# Altium schematic sheet RUMBA_plus.SchDoc (continued)

## Library data of the parts
5V 12V FAN0 FAN1 PS_ON PWR = Header 2: Header, 2-Pin; Manufacturer 1: Generic Header; Note: Approved sub OK; Component Kind: Standard; Signal Integrity: Connector; Library Name: RUMBA_v2.SCHLIB
C1 C21-C25 C30-C32 = CapE-6.3-100uF-35V: CAP ALUM 100UF 20% 35V SMD; Note: Approved sub OK; Component Kind: Standard; Published: 8-Jun-2000; Revision: July-2002: Re-released for DXP Platform.; Signal Integrity: Cap Pol; Simulation: CAP; Supplier 1: Digi-Key; Supplier Part Number 1: PCE5016CT-ND; Library Name: RUMBA_v2.SCHLIB; Publisher: Altium Limited
C2 C35 = CapC-0805-4.7uF 10V: CAP CER 4.7UF 10V X7R 0805; Note: Approved sub OK; Tolerance: 10%; Component Kind: Standard; Library Name: main_library.SCHLIB; Package: 0805; Revision: July-2002: Re-released for DXP Platform.; Signal Integrity: Cap Semi; Simulation: CAP; Supplier 1: Digi-Key; Supplier Part Number 1: 445-14527-1-ND; Type: X7R; Voltage: 10V
C3 C17 C36 = CapC-1210-4.7uF-50V: CAP CER 4.7UF 50V X7R 1210; Note: Approved sub OK; Component Kind: Standard; Published: 8-Jun-2000; Revision: July-2002: Re-released for DXP Platform.; Supplier 1: Digi-Key; Supplier Part Number 1: 399-6785-1-ND; Library Name: picoprint_library.SCHLIB; Publisher: Altium Limited
C4-C7 C10 C11 C13 C16 C18 C33 C34 = CapC-0603-100nF: CAP CER 0.1UF 50V X7R 0603; Note: Approved sub OK; Component Kind: Standard; Published: 8-Jun-2000; Revision: July-2002: Re-released for DXP Platform.; Signal Integrity: Cap Semi; Simulation: CAP; Supplier 1: Digi-Key; Supplier Part Number 1: 311-1344-1-ND; Library Name: RUMBA_v2.SCHLIB; Publisher: Altium Limited
C8 C14 C15 C19 C20 = CapC-0603-22pF: CAP CER 22PF 50V X7R 0603; Note: Approved sub OK; Component Kind: Standard; Published: 8-Jun-2000; Revision: July-2002: Re-released for DXP Platform.; Signal Integrity: Cap Semi; Simulation: CAP; Supplier 1: Digi-Key; Supplier Part Number 1: 478-6204-1-ND; Library Name: RUMBA_v2.SCHLIB; Publisher: Altium Limited
C9 C12 C26-C29 = CapC-0603-1uF 50V: CAP CER 1UF 16V X7R 0603; Note: Approved sub OK; Tolerance: 10%; Component Kind: Standard; Library Name: main_library.SCHLIB; Package: 0603; Revision: July-2002: Re-released for DXP Platform.; Signal Integrity: Cap Semi; Simulation: CAP; Supplier 1: Digi-Key; Supplier Part Number 1: 587-1241-1-ND; Type: X7R; Voltage: 16V
D2 D3 = DIODE_75V_500mW: DIODE GEN PURP 75V 150MA SOD323; Note: Approved sub OK; Ratings: 75V; 300mA; 0.5W; Value: Vf=1V @ 50mA; Component Kind: Standard; Library Name: PBL - Diodes.SCHLIB; Package / Case: SOD323; Supplier 1: Digi-Key; Supplier Part Number 1: 1N4148WXTPMSCT-ND
D4 D5 D15 = Diode Schottky 2A 40V: DIODE SCHOTTKY 40V 2A SOD123F; Note: Approved sub OK; Component Kind: Standard; Revision: July-2002: Re-released for DXP Platform.; Signal Integrity: Diode; Simulation: DIODE; Supplier 1: Digi-Key; Supplier Part Number 1: SS24FLCT-ND; Library Name: picoprint_library.SCHLIB
D6 D7 D9-D14 = DIODE_100V_1.5A_SMA: DIODE GEN PURP 100V 1.5A SMA; Diode Type: Standard; Material: Si; Note: Approved sub OK; Ratings: 100V; 1.5A; SCDType: source; Value: Vf = 1.15V @ 1.5A (Max); Component Kind: Standard; Library Name: PBL - Diodes.SCHLIB; Package / Case: DO-214AC, SMA; Supplier 1: Digi-Key; Supplier Part Number 1: S2BA-FDICT-ND
D8 = Diode Schottky - 40V 4A - SSB44-E3: DIODE SCHOTTKY 40V 4A DO214AA; Note: Approved sub OK; Component Kind: Standard; Revision: July-2002: Re-released for DXP Platform.; Supplier 1: Digi-Key; Supplier Part Number 1: SSB44-E3/52TGICT-ND; Library Name: RUMBA_library.SCHLIB
E0-E2 X Y Z = A4988BREAKOUT: Note: Approved sub OK; Supplier 1: Digi-Key; Supplier Part Number 1: S7006-ND; Library Name: RUMBA_v2.SCHLIB
E0_0 E0_1 E1_0 E1_1 E2_0 E2_1 X_1 X_2 Y_0 Y_1 Z_0 Z_1 = Header 8x1: Header, 8-Pin, Female; Manufacturer 1: Generic Header; Note: Approved sub OK; Signal Integrity: Connector; Library Name: picoprint_library.SCHLIB
E0_MOT E1_MOT E2_MOT X_MOT Y_MOT Z_MOT = Header 4_1: Header, 4-Pin; Manufacturer 1: Generic Header; Note: Approved sub OK; Component Kind: Standard; Signal Integrity: Connector; Library Name: RUMBA_v2.SCHLIB
E0_MOT_A E1_MOT_A E2_MOT_A X_MOT_A Y_MOT_A Z_MOT_A = ScrewTerminal-3.5mm-4Way: TERM BLK 4POS SIDE ENT 3.5MM PCB; Note: Approved sub OK; Component Kind: Standard; LatestRevisionDate: 17-Jul-2002; LatestRevisionNote: Re-released for DXP Platform.; Signal Integrity: Connector; Supplier 1: Digi-Key; Supplier Part Number 1: 277-5744-ND; Library Name: RUMBA_v2.SCHLIB; Publisher: Altium Limited
E0_MS E1_MS E2_MS X_MS Y_MS Z_MS = SW DIP-3: SWITCH SLIDE DIP SPST 25MA 24V; Note: Approved sub OK; Component Kind: Standard; Revision: July-2002: Re-released for DXP Platform.; Simulation: dpsw8; Supplier 1: Digi-Key; Supplier Part Number 1: 732-3853-1-ND; Library Name: RUMBA_v2.SCHLIB
  E0_MS E1_MS X_MS Y_MS Z_MS: Published: 24-Mar-1999; Publisher: Altium Limited
ENC_1-ENC_4 = Header 4: Header, 4-Pin; Manufacturer 1: Generic Header; Note: Approved sub OK; Component Kind: Standard; Library Name: Miscellaneous Connectors.IntLib; Signal Integrity: Connector
EXP1 EXP2 JTAG Thermistors = Header 5X2: Header, 5-Pin, Dual row; Manufacturer 1: Generic Header; Note: Approved sub OK; Component Kind: Standard; Signal Integrity: Connector; Library Name: RUMBA_v2.SCHLIB
EXP3 = Header 7X2: Header, 7-Pin, Dual row; Manufacturer 1: Generic Header; Note: Approved sub OK; Component Kind: Standard; Signal Integrity: Connector; Library Name: RUMBA_v2.SCHLIB
F1 F2 = Fuseholder - Mini ATC ATO 15A: FUSE HOLDER BLADE PCB; Note: Approved sub OK; Component Kind: Standard; Library Name: Schlib1.SchLib; Supplier 1: Digi-Key; Supplier Part Number 1: BK-6013-ND
F3 = Fuse - 15V 200mA Trip PTC SMD 0603: PTC RESET FUSE 9V 200MA 0603; Note: Approved sub OK; Ratings: 160mA; 63VDC; slow-blow; Component Kind: Standard; Library Name: Schlib1.SchLib; Package / Case: 0603 (1608 metric); Supplier 1: Digi-Key; Supplier Part Number 1: 507-1821-1-ND
FAN0_1 FAN1_1 HE0-HE2 = ScrewTerminal-3.5mm-2Way: TERM BLK 2POS SIDE ENT 3.5MM PCB; Note: Approved sub OK; Component Kind: Standard; Supplier 1: Digi-Key; Supplier Part Number 1: 277-5719-ND; Library Name: RUMBA_v2.SCHLIB
HB-OUT HB-PWR MAIN-PWR = ScrewTerminal-5mm-2Way: TERM BLK 2POS SIDE ENTRY 5MM PCB; Component Kind: Standard; Supplier 1: Digi-Key; Supplier Part Number 1: 277-6405-ND; Library Name: RUMBA_v2.SCHLIB
ISP1 ISP2 = Header 3X2: Header, 3-Pin, Dual row; Manufacturer 1: Generic Header; Note: Approved sub OK; Component Kind: Standard; Signal Integrity: Connector; Library Name: RUMBA_v2.SCHLIB
J2 = Micro-USB B Socket: CONN RCPT USB2.0 MICRO B SMD R/A; Note: Approved sub OK; Component Kind: Standard; Supplier 1: Digi-Key; Supplier Part Number 1: WM1399CT-ND; Library Name: picoprint_library.SCHLIB
L1 = Ferrite Bead SMD 0805: FERRITE BEAD 220 OHM 0805 1LN; Note: Approved sub OK; Component Kind: Standard; Revision: July-2002: Re-released for DXP Platform.; Signal Integrity: Inductor Iron Dot; Supplier 1: Digi-Key; Supplier Part Number 1: 490-1054-1-ND; Library Name: RUMBA_v2.SCHLIB
L3 = Inductor SMD 33uH 2.5A: FIXED IND 33UH 1.8A 145 MOHM SMD; Note: Approved sub OK; Component Kind: Standard; Revision: July-2002: Re-released for DXP Platform.; Supplier 1: Digi-Key; Supplier Part Number 1: SRN6045TA-330MCT-ND; Library Name: RUMBA_v2.SCHLIB
L4 = Inductor SMD 33uH 2.5A: FIXED IND 4.7UH 4.5A 26 MOHM SMD; Note: Approved sub OK; Component Kind: Standard; Published: 24-Mar-1999; Revision: July-2002: Re-released for DXP Platform.; Supplier 1: Digi-Key; Supplier Part Number 1: SRN6045TA-4R7MCT-ND; Library Name: RUMBA_v2.SCHLIB; Publisher: Altium Limited
LD1-LD10 = LEDG  0603: LED GREEN CLEAR CHIP SMD 0603; Note: Approved sub OK; Component Kind: Standard; Supplier 1: Digi-Key; Supplier Part Number 1: 160-1435-2-ND; Library Name: picoprint_library.SCHLIB
LED1 RX TX = LEDY  0603: LED YELLOW CLEAR CHIP SMD 0603; Note: Approved sub OK; Component Kind: Standard; Supplier 1: Digi-Key; Supplier Part Number 1: 160-1437-1-ND; Library Name: picoprint_library.SCHLIB
OS_logo = Logo: Note: Approved sub OK; Library Name: gc.SCHLIB
Q1-Q5 = MOSFET - BUK9Y21-40E: MOSFET N-CH 40V 33A LFPAK; Component Kind: Standard; Supplier 1: Digi-Key; Supplier Part Number 1: 1727-1123-1-ND; Library Name: RUMBA_library.SCHLIB
Q6 = MOSFET - PSMN1R8-40YLC: MOSFET N-CH 40V 100A LFPAK; Component Kind: Standard; Supplier 1: Digi-Key; Supplier Part Number 1: 1727-1052-1-ND; Library Name: RUMBA_library.SCHLIB
R1 R9 = Res-0603-1M: RES SMD 1M OHM 1% 1/10W 0603; Note: Approved sub OK; Component Kind: Standard; Published: 8-Jun-2000; Revision: July-2002: Re-released for DXP Platform.; Signal Integrity: Res; Simulation: RESISTOR; Supplier 1: Digi-Key; Supplier Part Number 1: 311-1.00MHRDKR-ND; Library Name: RUMBA_v2.SCHLIB; Publisher: Altium Limited
R2 R3 R6 R11 R12 R21 R23 R25 R27 R29 R45 R57 = Res-0603-10K: RES SMD 10K OHM 1% 1/10W 0603; Note: Approved sub OK; Component Kind: Standard; Published: 8-Jun-2000; Revision: July-2002: Re-released for DXP Platform.; Signal Integrity: Res; Simulation: RESISTOR; Supplier 1: Digi-Key; Supplier Part Number 1: 311-10.0KHRCT-ND; Library Name: RUMBA_v2.SCHLIB; Publisher: Altium Limited
R4 R13-R18 R20 R33 R36 R39 R50 R53 R55 R58 = Res-0603-1K: RES SMD 1K OHM 1% 1/10W 0603; Note: Approved sub OK; Component Kind: Standard; Published: 8-Jun-2000; Revision: July-2002: Re-released for DXP Platform.; Signal Integrity: Res; Simulation: RESISTOR; Supplier 1: Digi-Key; Supplier Part Number 1: 311-1.00KHRCT-ND; Library Name: RUMBA_v2.SCHLIB; Publisher: Altium Limited
R5 R19 = Res-0603-10K: RES SMD 54.9K OHM 1% 1/10W 0603; Note: Approved sub OK; Component Kind: Standard; Published: 8-Jun-2000; Revision: July-2002: Re-released for DXP Platform.; Signal Integrity: Res; Simulation: RESISTOR; Supplier 1: Digi-Key; Supplier Part Number 1: 311-54.9KHRCT-ND; Library Name: RUMBA_v2.SCHLIB; Publisher: Altium Limited
R7 R8 R31 R34 R37 R46 R48 R51 = Res-0603-22R: RES SMD 22 OHM 1% 1/10W 0603; Note: Approved sub OK; Component Kind: Standard; Published: 8-Jun-2000; Revision: July-2002: Re-released for DXP Platform.; Signal Integrity: Res; Simulation: RESISTOR; Supplier 1: Digi-Key; Supplier Part Number 1: 311-22.0HRCT-ND; Library Name: RUMBA_v2.SCHLIB; Publisher: Altium Limited
R10 R22 R24 R26 R28 R30 R32 R35 R38 R44 R47 R49 R52 = Res-0603-100K: RES SMD 100K OHM 1% 1/10W 0603; Note: Approved sub OK; Component Kind: Standard; Published: 8-Jun-2000; Revision: July-2002: Re-released for DXP Platform.; Signal Integrity: Res; Simulation: RESISTOR; Supplier 1: Digi-Key; Supplier Part Number 1: 311-100KHRCT-ND; Library Name: RUMBA_v2.SCHLIB; Publisher: Altium Limited
R40-R43 R54 R59 = Res-0603-4K7: RES SMD 4.7K OHM 1% 1/10W 0603; Note: Approved sub OK; Component Kind: Standard; Published: 8-Jun-2000; Revision: July-2002: Re-released for DXP Platform.; Signal Integrity: Res; Simulation: RESISTOR; Supplier 1: Digi-Key; Supplier Part Number 1: 311-4.70KHRCT-ND; Library Name: RUMBA_v2.SCHLIB; Publisher: Altium Limited
R56 = Res-0603-1K: RES SMD 140K OHM 1% 1/10W 0603; Note: Approved sub OK; Component Kind: Standard; Published: 8-Jun-2000; Revision: July-2002: Re-released for DXP Platform.; Signal Integrity: Res; Simulation: RESISTOR; Supplier 1: Digi-Key; Supplier Part Number 1: 311-140KHRCT-ND; Library Name: RUMBA_v2.SCHLIB; Publisher: Altium Limited
Reset = SW-PB: SWITCH TACTILE SPST-NO 0.05A 12V; Note: Approved sub OK; Component Kind: Standard; Supplier 1: Digi-Key; Supplier Part Number 1: 679-2396-1-ND; Library Name: RUMBA_v2.SCHLIB
sc1-sc4 = Sraf: Note: Approved sub OK; Library Name: gc.SCHLIB
U1 = ATMEGA2560: IC MCU 8BIT 256KB FLASH 100TQFP; Component Kind: Standard; Supplier 1: Digi-Key; Supplier Part Number 1: ATMEGA2560-16AU-ND; Library Name: RUMBA_v2.SCHLIB
U2 = ATMEGA16U2-MU: IC MCU 8BIT 16KB FLASH 32VQFN; Component Kind: Standard; Supplier 1: Digi-Key; Supplier Part Number 1: ATMEGA16U2-MU-ND; Library Name: RUMBA_v2.SCHLIB
U3 = Buck Regulator AOZ1282CI: IC REG BUCK ADJ 1.2A SOT23-6; Component Kind: Standard; Library Name: Schlib1.SchLib; Supplier 1: Digi-Key; Supplier Part Number 1: 785-1613-1-ND
U4 = Regulator - AOZ1284 4A Buck Regulator: IC REG BUCK ADJ 4A 8SO; Component Kind: Standard; Supplier 1: Digi-Key; Supplier Part Number 1: 785-1689-1-ND; Library Name: picoprint_library.SCHLIB
U5 = USBLC6-4SC6 USB ESD Protection: TVS DIODE 5.25V 17V SOT23-6; Note: Approved sub OK; Component Kind: Standard; Supplier 1: Digi-Key; Supplier Part Number 1: 497-4492-1-ND; Library Name: picoprint_library.SCHLIB
USB_AUX = Header 5x1: Header, 5-Pin; Manufacturer 1: Generic Header; Note: Approved sub OK; Component Kind: Standard; Signal Integrity: Connector; Library Name: picoprint_library.SCHLIB
VFA0_sel VFA1_sel VHE2_sel X+ X- Y+ Y- Z+ Z- = Header 3: Header, 3-Pin; Manufacturer 1: Generic Header; Note: Approved sub OK; Component Kind: Standard; Signal Integrity: Connector; Library Name: RUMBA_v2.SCHLIB
Y1 Y2 = XTAL_2: CRYSTAL 16.0000MHZ 18PF SMD; Note: Approved sub OK; Component Kind: Standard; Supplier 1: Digi-Key; Supplier Part Number 1: SER3686CT-ND; Library Name: RUMBA_v2.SCHLIB
